# Altium schematic sheet eps_32m1.SchDoc
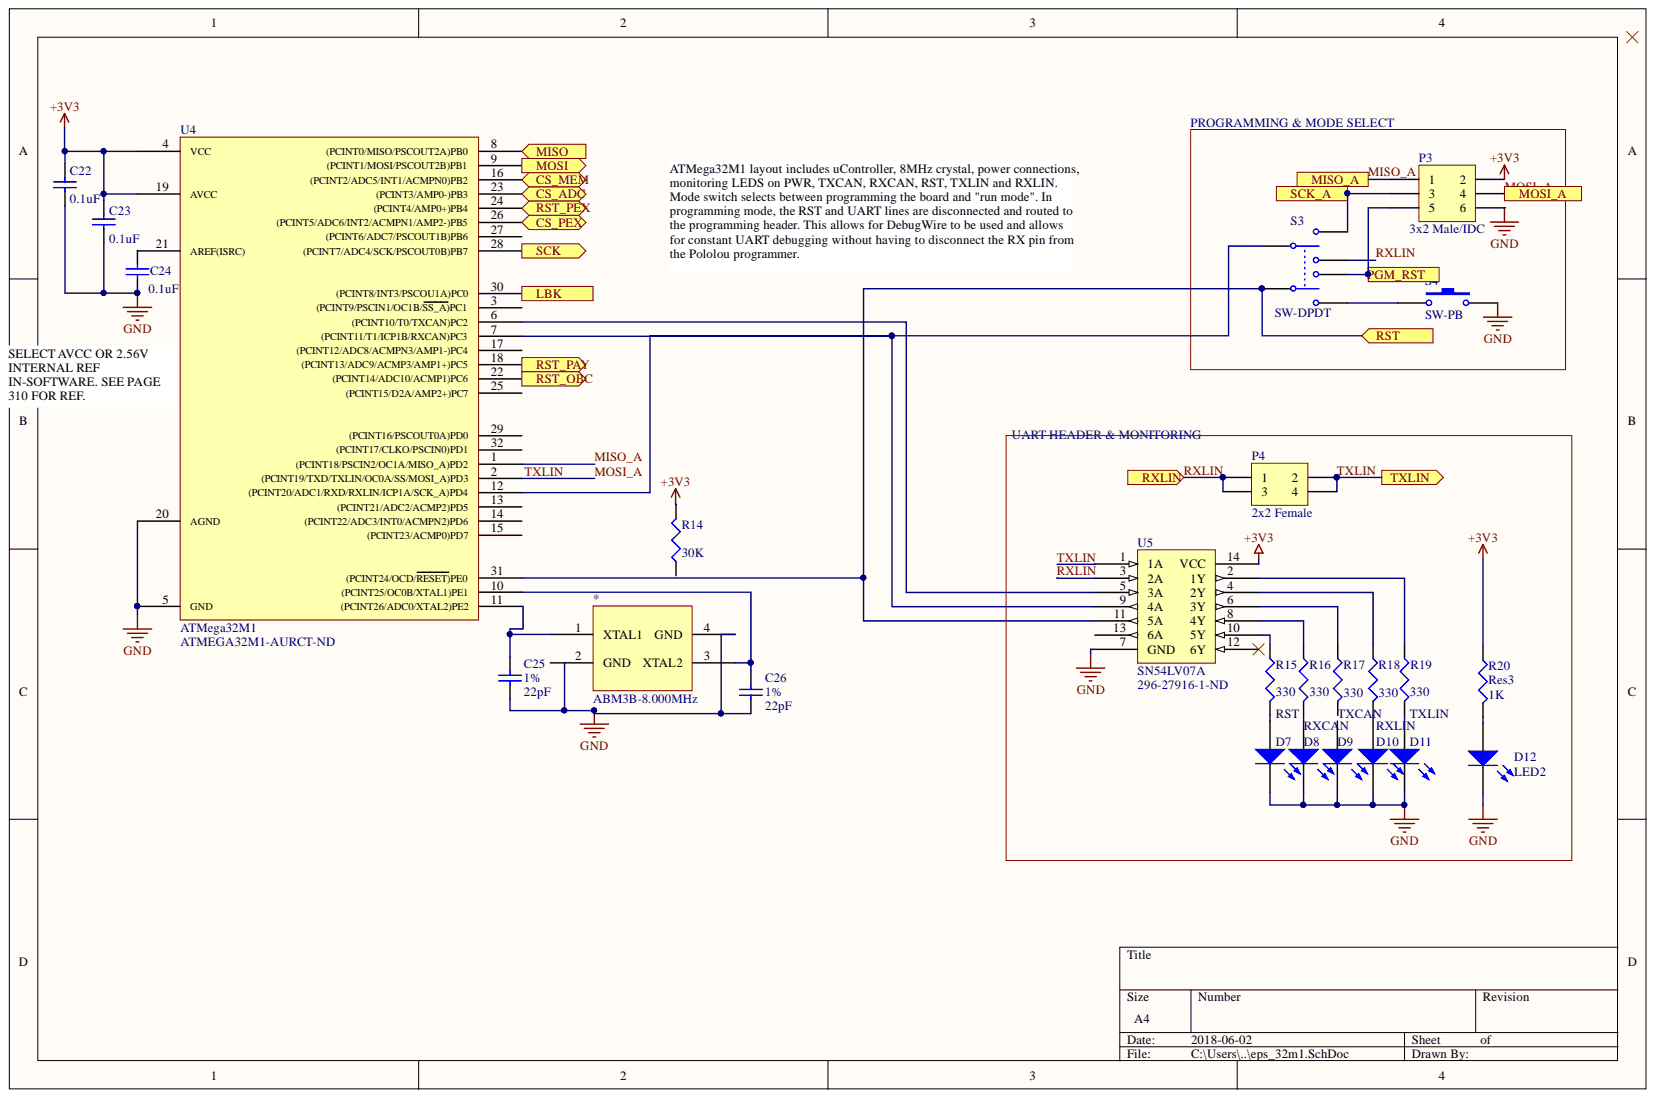
[diagram p0: eps_32m1.SchDoc]

## Parts
*  ABM3B-8.000MHz  [OSCSC500X320X110-4N]
C22  value 0.1uF  [1608[0603]]  (hidden comment: Cap Semi)
C23  value 0.1uF  [1608[0603]]  (hidden comment: Cap Semi)
C24  value 0.1uF  [1608[0603]]  (hidden comment: Cap Semi)
C25  1%  value 22pF  [1608[0603]]
C26  1%  value 22pF  [1608[0603]]
D7  RST  [1608[0603]]
D8  RXCAN  [1608[0603]]
D9  TXCAN  [1608[0603]]
D10  RXLIN  [1608[0603]]
D11  TXLIN  [1608[0603]]
D12  LED2  [1608[0603]]
P3  3x2 Male/IDC  [HDR2X3]
P4  2x2 Female  [HDR2X2]
R14  value 30K  [J1-0603]
R15  value 330  [J1-0603]  (hidden comment: Res3)
R16  value 330  [J1-0603]  (hidden comment: Res3)
R17  value 330  [J1-0603]  (hidden comment: Res3)
R18  value 330  [J1-0603]  (hidden comment: Res3)
R19  value 330  [J1-0603]  (hidden comment: Res3)
R20  Res3  value 1K  [J1-0603]
S3  SW-DPDT  [DPDT-6]
S4  SW-PB  [SPST-2]
U4  ATMega32M1  Supplier Part Number 1: ATMEGA32M1-AURCT-ND  [QFP80P900X900X120-32N]
U5  SN54LV07A  Supplier Part Number: 296-27916-1-ND  [SOP65P640X120-14N]

## Ports
CS_ADC, CS_MEM, CS_PEX, LBK, MISO, MISO_A, MOSI, MOSI_A, PGM_RST, RST, RST_OBC, RST_PAY, RST_PEX, RXLIN, SCK, SCK_A, TXLIN

## Nets
+3V3: C22.2 C23.2 P3.2 R14.2 R20.1 U4.4(VCC) U4.19(AVCC) U5.14(VCC)
GND: *.4(GND) C22.1 C23.1 C24.2 C25.1 C26.1 D7.2 D8.2 D9.2 D10.2 D11.2 D12.2 P3.6 S4.2 U4.5(GND) U4.20(AGND) U5.7(GND)
CS_ADC: U4.23((PCINT3/AMP0-)PB3) ; port CS_ADC
CS_MEM: U4.16((PCINT2/ADC5/INT1/ACMPN0)PB2) ; port CS_MEM
CS_PEX: U4.26((PCINT5/ADC6/INT2/ACMPN1/AMP2-)PB5) ; port CS_PEX
LBK: U4.30((PCINT8/INT3/PSCOU1A)PC0) ; port LBK
MISO: U4.8((PCINT0/MISO/PSCOUT2A)PB0) ; port MISO
MISO_A: P3.1 U4.1((PCINT18/PSCIN2/OC1A/MISO_A)PD2) ; port MISO_A
MOSI: U4.9((PCINT1/MOSI/PSCOUT2B)PB1) ; port MOSI
MOSI_A: P3.4 P4.2 P4.4 U4.2((PCINT19/TXD/TXLIN/OC0A/SS/MOSI_A)PD3) U5.1(1A) ; port MOSI_A, port TXLIN
PGM_RST: P3.5 S3.5 ; port PGM_RST
RST: S3.4 U4.31((PCINT24/OCD/RESET)PE0) U5.11(5A) ; port RST
RST_OBC: U4.22((PCINT14/ADC10/ACMP1)PC6) ; port RST_OBC
RST_PAY: U4.18((PCINT13/ADC9/ACMP3/AMP1+)PC5) ; port RST_PAY
RST_PEX: U4.24((PCINT4/AMP0+)PB4) ; port RST_PEX
RXLIN: P4.1 P4.3 S3.3 U5.3(2A) ; port RXLIN
SCK: U4.28((PCINT7/ADC4/SCK/PSCOUT0B)PB7) ; port SCK
SCK_A: P3.3 S3.2 ; port SCK_A
Net*_1: *.1(XTAL1) C25.2 U4.11((PCINT26/ADC0/XTAL2)PE2)
Net*_3: *.3(XTAL2) C26.2 U4.10((PCINT25/OC0B/XTAL1)PE1)
NetC24_1: C24.1 U4.21(AREF(ISRC))
NetD7_1: D7.1 R15.2
NetD8_1: D8.1 R16.2
NetD10_1: D10.1 R18.2
NetD11_1: D11.1 R19.2
NetD12_1: D12.1 R20.2
NetR15_1: R15.1 U5.10(5Y)
NetR16_1: R16.1 U5.8(4Y)
NetR17_1: R17.1 U5.6(3Y)
NetR18_1: R18.1 U5.4(2Y)
NetR19_1: R19.1 U5.2(1Y)
NetS3_1: S3.1 U4.7((PCINT11/T1/ICP1B/RXCAN)PC3) U4.12((PCINT20/ADC1/RXD/RXLIN/ICP1A/SCK_A)PD4) U5.9(4A)
NetS3_6: S3.6 S4.1
NetU4_6: U4.6((PCINT10/T0/TXCAN)PC2) U5.5(3A)

Not connected: * 2(GND); D9 1; R14 1; R17 2; U4 3((PCINT9/PSCIN1/OC1B/SS_A)PC1) 13((PCINT21/ADC2/ACMP2)PD5) 14((PCINT22/ADC3/INT0/ACMPN2)PD6) 15((PCINT23/ACMP0)PD7) 17((PCINT12/ADC8/ACMPN3/AMP1-)PC4) 25((PCINT15/D2A/AMP2+)PC7) 27((PCINT6/ADC7/PSCOUT1B)PB6) 29((PCINT16/PSCOUT0A)PD0) 32((PCINT17/CLKO/PSCIN0)PD1); U5 12(6Y) 13(6A)

## Library data of the parts
C22-C26 = Cap Semi: Capacitor (Semiconductor SIM Model); Component Kind: Standard; LatestRevisionDate: 29-May-2009; LatestRevisionNote: IPC-7351 Footprint Added.; Library Name: Miscellaneous Devices.IntLib; PackageDescription: Chip Capacitor; PackageReference: C1206; Published: 8-Jun-2000; Signal Integrity: Cap Semi; Simulation: CAP; Publisher: Altium Limited
D7-D12 = LED2: Typical RED, GREEN, YELLOW, AMBER GaAs LED; Component Kind: Standard; LatestRevisionDate: 17-Jul-2002; LatestRevisionNote: Re-released for DXP Platform.; Library Name: Miscellaneous Devices.IntLib; PackageDescription: SM LED; 2 Flat Leads; PackageReference: 3.2X1.6X1.1; PackageVersion: Feb-2002; Published: 8-Jun-2000; Simulation: LED2; Publisher: Altium Limited
P3 = Header 3X2: 2x3 through-hole programming header, IDC; Component Kind: Standard; LatestRevisionDate: 17-Jul-2002; LatestRevisionNote: Re-released for DXP Platform.; Library Name: Miscellaneous Connectors.IntLib; Signal Integrity: Connector; Publisher: Altium Limited
P4 = Header 2X2: Header, 2-Pin, Dual row; Component Kind: Standard; LatestRevisionDate: 17-Jul-2002; LatestRevisionNote: Re-released for DXP Platform.; Library Name: Miscellaneous Connectors.IntLib; Signal Integrity: Connector; Publisher: Altium Limited
R14 = Res3: 30K Pull-up resistor for RST. See page; Component Kind: Standard; LatestRevisionDate: 29-May-2009; LatestRevisionNote: IPC-7351 Footprint Added.; Library Name: Miscellaneous Devices.IntLib; PackageDescription: Chip Resistor; PackageReference: J1-0603; Published: 8-Jun-2000; Signal Integrity: Res; Simulation: RESISTOR; Publisher: Altium Limited
R15-R20 = Res3: Resistor; Component Kind: Standard; LatestRevisionDate: 29-May-2009; LatestRevisionNote: IPC-7351 Footprint Added.; Library Name: Miscellaneous Devices.IntLib; PackageDescription: Chip Resistor; PackageReference: J1-0603; Published: 8-Jun-2000; Signal Integrity: Res; Simulation: RESISTOR; Publisher: Altium Limited
S3 = SW-DPDT: Double-Pole, Double-Throw Switch; Component Kind: Standard; LatestRevisionDate: 17-Jul-2002; LatestRevisionNote: Re-released for DXP Platform.; Library Name: Miscellaneous Devices.IntLib; PackageDescription: Switch; 6 Leads; PackageReference: DPDT-6; Published: 24-Mar-1999; Publisher: Altium Limited
S4 = SW-PB: Switch; Component Kind: Standard; LatestRevisionDate: 17-Jul-2002; LatestRevisionNote: Re-released for DXP Platform.; Library Name: Miscellaneous Devices.IntLib; PackageDescription: Switch; 2 Leads; PackageReference: SPST-2; Published: 24-Mar-1999; Publisher: Altium Limited
U4 = ATMega32M1: Atmel 8-bit AVR microcontroller.; Component Kind: Standard; Supplier 1: Digi-Key; Library Name: heron-general.IntLib
U5 = SN54LV07A: Six element 1-bit non-inverting open-drain buffer.; Component Kind: Standard; Supplier: Digi-Key; Library Name: heron-general.IntLib
* = ABM3B-8.000MHz: Component Kind: Standard; Library Name: heron-general.IntLib
